# Altium schematic sheet isoUSBtoUART.SchDoc(isoUSBtoUART)
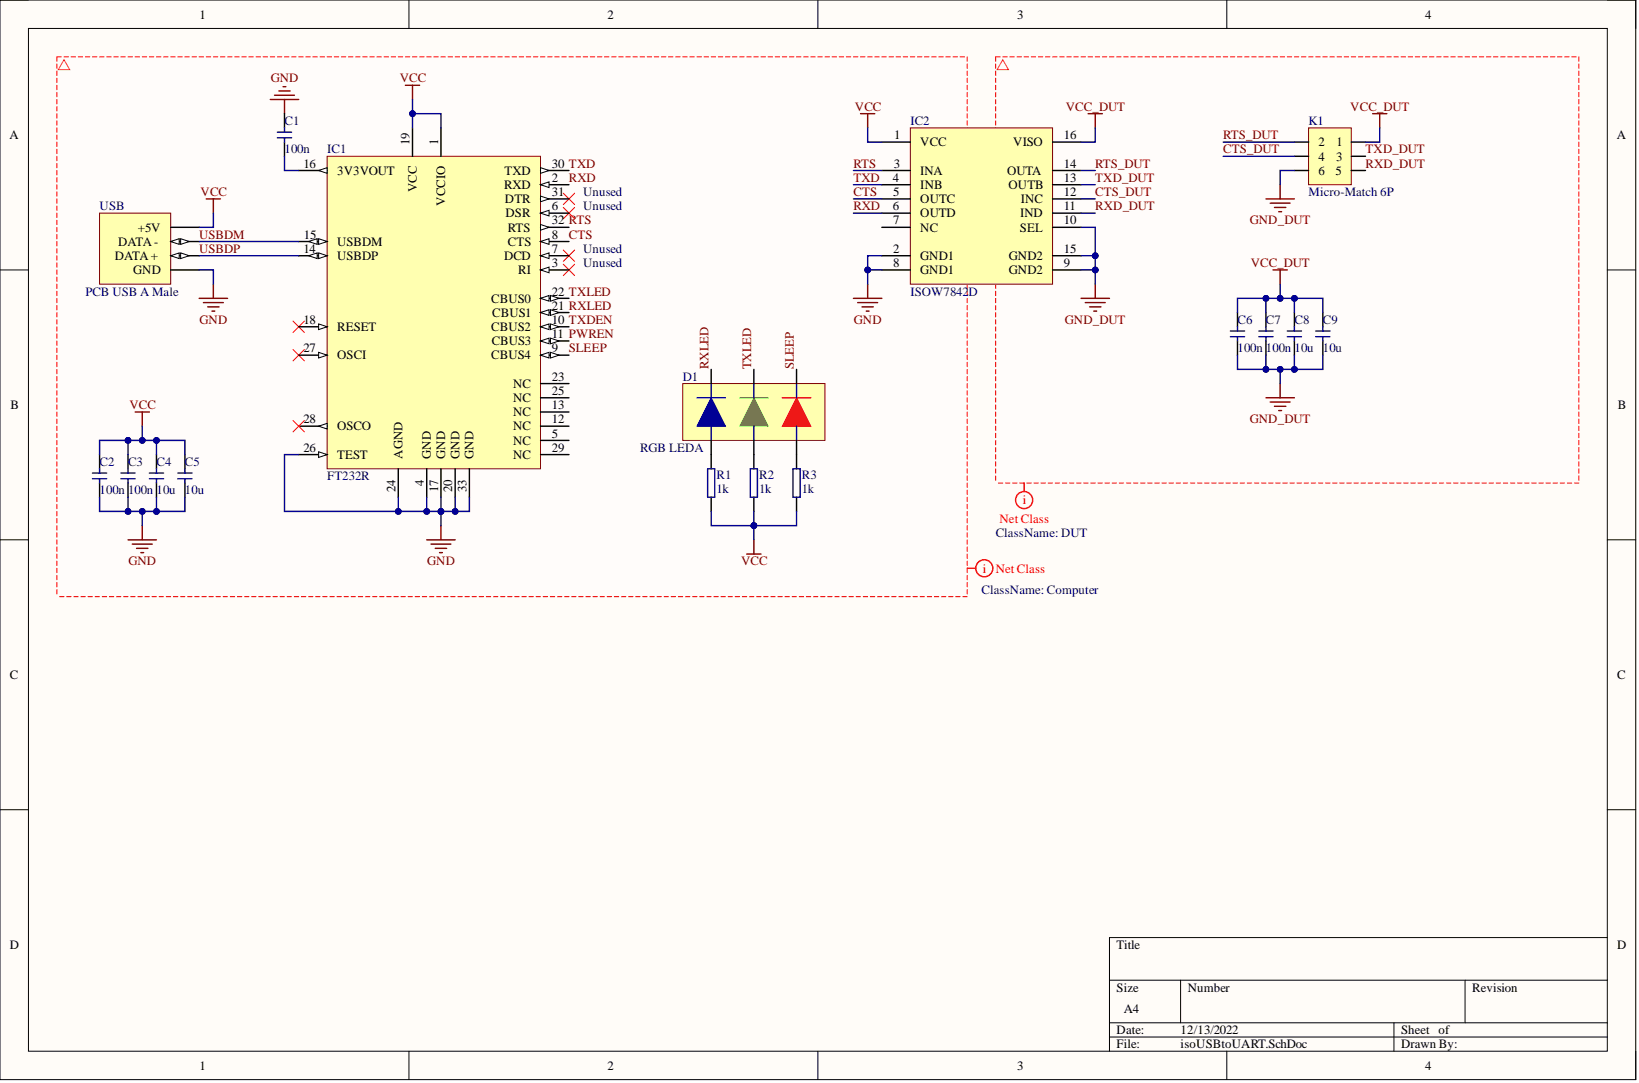
[diagram p0: isoUSBtoUART.SchDoc]

## Parts
C1  value 100n  (hidden comment: Capacitor)
C2  value 100n  (hidden comment: Capacitor)
C3  value 100n  (hidden comment: Capacitor)
C4  value 10u  (hidden comment: Capacitor)
C5  value 10u  (hidden comment: Capacitor)
C6  value 100n  (hidden comment: Capacitor)
C7  value 100n  (hidden comment: Capacitor)
C8  value 10u  (hidden comment: Capacitor)
C9  value 10u  (hidden comment: Capacitor)
D1  RGB LEDA
IC1  FT232R
IC2  ISOW7842D
K1  Micro-Match 6P
R1  value 1k  (hidden comment: Resistor)
R2  value 1k  (hidden comment: Resistor)
R3  value 1k  (hidden comment: Resistor)
USB  PCB USB A Male

## Nets
GND: C1.2 C2.1 C3.1 C4.1 C5.1 IC1.4(GND) IC1.17(GND) IC1.20(GND) IC1.24(AGND) IC1.26(TEST) IC1.33(GND) IC2.2(GND1) IC2.8(GND1) USB.4(GND)
GND_DUT: C6.1 C7.1 C8.1 C9.1 IC2.9(GND2) IC2.10(SEL) IC2.15(GND2) K1.6
VCC: C2.2 C3.2 C4.2 C5.2 IC1.1(VCCIO) IC1.19(VCC) IC2.1(VCC) R1.1 R2.1 R3.1 USB.1(+5V)
VCC_DUT: C6.2 C7.2 C8.2 C9.2 IC2.16(VISO) K1.1
CTS: IC1.8(CTS) IC2.5(OUTC)
CTS_DUT: IC2.12(INC) K1.4
PWREN: IC1.11(CBUS3)
RTS: IC1.32(RTS) IC2.3(INA)
RTS_DUT: IC2.14(OUTA) K1.2
RXD: IC1.2(RXD) IC2.6(OUTD)
RXD_DUT: IC2.11(IND) K1.5
RXLED: D1.1 IC1.21(CBUS1)
SLEEP: D1.3 IC1.9(CBUS4)
TXD: IC1.30(TXD) IC2.4(INB)
TXD_DUT: IC2.13(OUTB) K1.3
TXDEN: IC1.10(CBUS2)
TXLED: D1.2 IC1.22(CBUS0)
USBDM: IC1.15(USBDM) USB.2(DATA_-)
USBDP: IC1.14(USBDP) USB.3(DATA_+)
NetC1_1: C1.1 IC1.16(3V3VOUT)
NetD1_4: D1.4 R3.2
NetD1_5: D1.5 R2.2
NetD1_6: D1.6 R1.2

Not connected: IC1 3(RI) 5(NC) 6(DSR) 7(DCD) 12(NC) 13(NC) 18(RESET) 23(NC) 25(NC) 27(OSCI) 28(OSCO) 29(NC) 31(DTR); IC2 7(NC)

## Library data of the parts
D1 = RGB LEDA: RGB LED; Component Kind: Standard; Library Name: Schlib1.SchLib
IC1 = FT232R: USB to USART controller; Component Kind: Standard; Library Name: Schlib1.SchLib
IC2 = ISOW7842D: High-Performance, 5000-VRMS Reinforced Quad-Channel Digital Isolators With  Integrated High-Efficiency, Low-Emissions DC-DC Converter; Component Kind: Standard; Library Name: Schlib1.SchLib
K1 = Micro-Match 6P: 6 Pole Micro-Match connector; Component Kind: Standard; Library Name: Schlib1.SchLib
USB = PCB USB A Male: On PCB USB A male connector; Component Kind: Standard; Library Name: Schlib1.SchLib
C1-C9 = Capacitor: Component Kind: Standard; Description: Capacitor; Library Name: Schlib1.SchLib
R1-R3 = Resistor: Component Kind: Standard; Description: Resistor; Library Name: Schlib1.SchLib
